# Altium schematic sheet Converter_HV.SchDoc("Converter High Voltage")
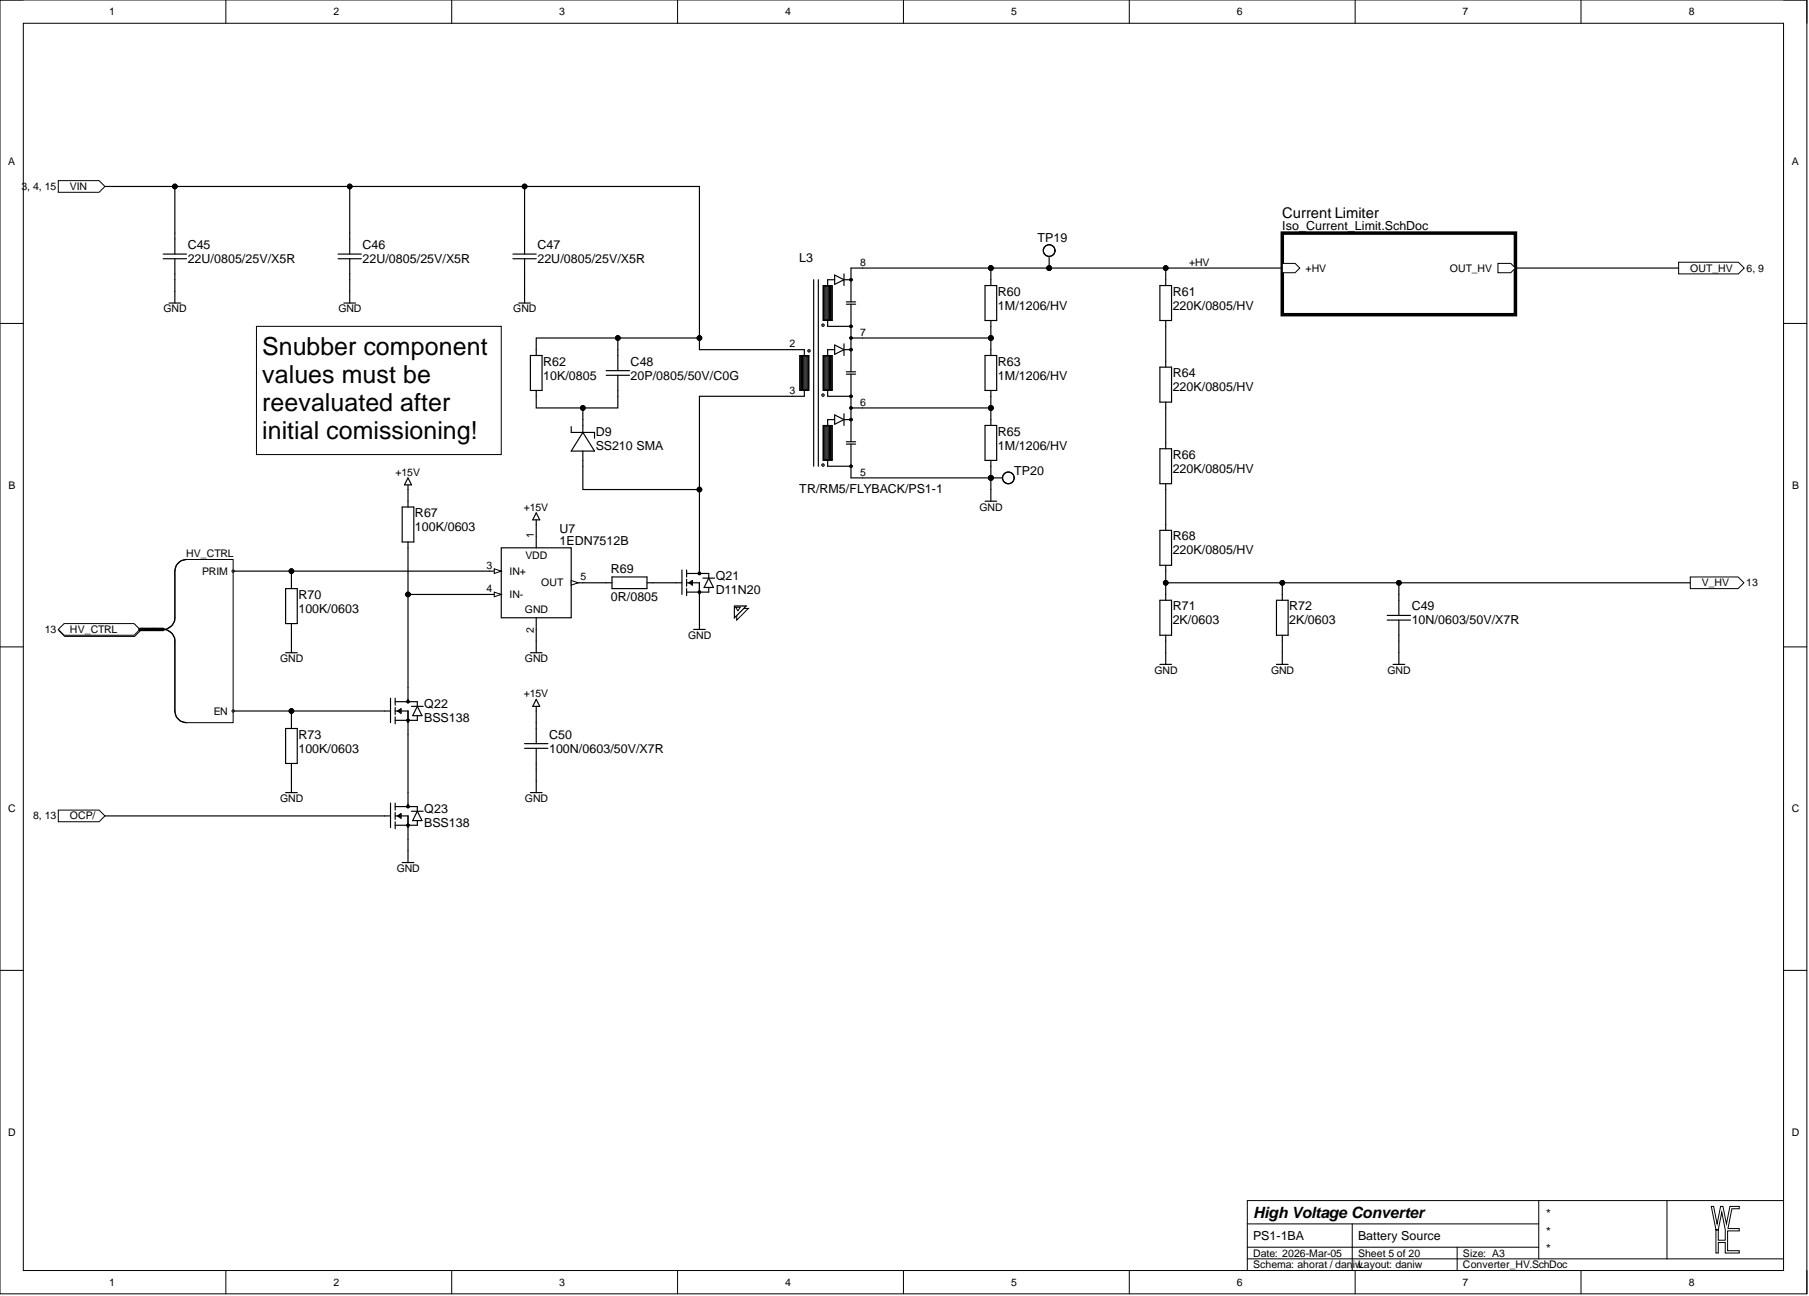
[diagram p0: Converter_HV.SchDoc]

## Parts
C45  22U/0805/25V/X5R  [CAP0805_X7R]
C46  22U/0805/25V/X5R  [CAP0805_X7R]
C47  22U/0805/25V/X5R  [CAP0805_X7R]
C48  20P/0805/50V/C0G  [CAP0805_C0G]
C49  10N/0603/50V/X7R  [CAP0603_X7R]
C50  100N/0603/50V/X7R  [CAP0603_X7R]
D9  SS210 SMA  value SS210  Manufacturer Part Number 3: SS210  [DIOM5226X230]
L3  TR/RM5/FLYBACK/PS1-1  [TR_FLYBACK_RM5_PS1-100]
Q21  D11N20  [TO228P990X229-3]
Q22  BSS138  [SOT2395P280X125-3-SE]
Q23  BSS138  [SOT2395P280X125-3-SE]
R60  1M/1206/HV  [R1206-0.80-WW]
R61  220K/0805/HV  [R0805-0.50-HV-WW]
R62  10K/0805  [R0805-0.50-WW]
R63  1M/1206/HV  [R1206-0.80-WW]
R64  220K/0805/HV  [R0805-0.50-HV-WW]
R65  1M/1206/HV  [R1206-0.80-WW]
R66  220K/0805/HV  [R0805-0.50-HV-WW]
R67  100K/0603  [R0603-0.40-WW]
R68  220K/0805/HV  [R0805-0.50-HV-WW]
R69  0R/0805  [R0805-0.50-WW]
R70  100K/0603  [R0603-0.40-WW]
R71  2K/0603  [R0603-0.40-WW]
R72  2K/0603  [R0603-0.40-WW]
R73  100K/0603  [R0603-0.40-WW]
TP19  TP_THD_1.5/0.8  [TP_THD_1.5/0.8]  (value not drawn)  (no BOM)
TP20  TP_THD_1.5/0.8  [TP_THD_1.5/0.8]  (value not drawn)  (no BOM)
U7  1EDN7512B  [SOT2395P280X125-5-NW]

## Ports
HV_CTRL, HV_CTRL.EN, HV_CTRL.PRIM, OCP/, OUT_HV, V_HV, VIN

## Nets
+15V: C50.1 R67.1 U7.1
GND: C45.2 C46.2 C47.2 C49.2 C50.2 L3.5 Q21.2 Q23.2 R65.2 R70.2 R71.2 R72.2 R73.2 TP20.1 U7.2
+HV: L3.8 R60.1 R61.1 TP19.1
OCP/: Q23.1 ; port OCP/
V_HV: C49.1 R68.2 R71.1 R72.1 ; port V_HV
VIN: C45.1 C46.1 C47.1 C48.1 L3.2 R62.1 ; port VIN
NetC48_2: C48.2 D9.1 R62.2
NetD9_2: D9.2 L3.3 Q21.3
NetL3_6: L3.6 R63.2 R65.1
NetL3_7: L3.7 R60.2 R63.1
NetQ21_1: Q21.1 R69.2
NetQ22_1: Q22.1 R73.1 ; port HV_CTRL
NetQ22_2: Q22.2 Q23.3
NetQ22_3: Q22.3 R67.2 U7.4(IN-)
NetR61_2: R61.2 R64.1
NetR64_2: R64.2 R66.1
NetR66_2: R66.2 R68.1
NetR69_1: R69.1 U7.5(OUT)
NetR70_1: R70.1 U7.3(IN+) ; port HV_CTRL
N00010: ; port OUT_HV

## Library data of the parts
C45-C47 = 22U/0805/25V/X5R: CAP SM 0805 22uF 25V X5R; Manufacturer: GENERIC; Manufacturer 1: Samsung Electro-Mechanics; Manufacturer Part Number 1: CL21A226MAYNNNE; Absolute Value: 2.2E-5; Database Table Name: C$; Eurocircuits GPN: GPN0805106-25; Component Kind: Standard; HelpURL: https://mm.digikey.com/Volume0/opasdata/d220001/medias/docus/339/CL21A226MAYNNNE_Spec.pdf; JLC Type: Basic; Manufacturer Part Number 3: CL21A226MAQNNNE; Package: 0805; Part Number: 22U/0805/25V/X5R; Supplier 1: Digi-Key; Supplier 3: LCSC; Supplier Part Number 1: 1276-CL21A226MAYNNNECT-ND; Supplier Part Number 3: C45783; Value: 22uF; Library Name: daniw_altium_lib.DbLib
C48 = 20P/0805/50V/C0G: CAP SM 0805 20pF 50V C0G; Manufacturer: GENERIC; Manufacturer 1: Samsung Electro-Mechanics; Manufacturer Part Number 1: CL21C200JBANNNC; Absolute Value: 2E-11; Database Table Name: C$; Component Kind: Standard; HelpURL: https://weblib.samsungsem.com/mlcc/mlcc-ec-data-sheet.do?partNumber=CL21C200JBANNN; JLC Type: Basic; Manufacturer Part Number 3: CL21C200JBANNNC; Package: 0805; Part Number: 20P/0805/50V/C0G; Supplier 1: Digi-Key; Supplier 3: LCSC; Supplier Part Number 1: 1276-1829-2-ND; Supplier Part Number 3: C1798; Value: 20pF; Library Name: daniw_altium_lib.DbLib
C49 = 10N/0603/50V/X7R: CAP SM 0603 10nF 50V X7R; Manufacturer: GENERIC; Manufacturer 1: YAGEO; Manufacturer Part Number 1: CC0603KPX7R9BB103; Absolute Value: 1E-8; Database Table Name: C$; Eurocircuits GPN: GPC0603103; Component Kind: Standard; HelpURL: https://www.yageo.com/upload/media/product/app/datasheet/mlcc/upy-gphc_x7r_6_3v-to-250v.pdf; Manufacturer 2: YAGEO; Manufacturer Part Number 2: CC0603KRX7R9BB103; Manufacturer Part Number 3: CC0603KRX7R9BB103; Package: 0603; Part Number: 10N/0603/50V/X7R; Supplier 1: Digi-Key; Supplier 2: Digi-Key; Supplier 3: LCSC; Supplier Part Number 1: 311-1572-1-ND; Supplier Part Number 2: 311-1085-1-ND; Supplier Part Number 3: C100042; Value: 10nF; Library Name: daniw_altium_lib.DbLib
C50 = 100N/0603/50V/X7R: CAP SM 0603 100nF 50V X7R; Manufacturer: GENERIC; Manufacturer 1: YAGEO; Manufacturer Part Number 1: CC0603KPX7R9BB104; Absolute Value: 1E-7; Database Table Name: C$; Eurocircuits GPN: GPC0603104; Component Kind: Standard; HelpURL: https://www.yageo.com/upload/media/product/app/datasheet/mlcc/upy-gphc_x7r_6_3v-to-250v.pdf; JLC Type: Basic; Manufacturer 2: YAGEO; Manufacturer Part Number 2: CC0603MRX7R9BB104; Manufacturer Part Number 3: CC0603KRX7R9BB104; Package: 0603; Part Number: 100N/0603/50V/X7R; Supplier 1: Digi-Key; Supplier 2: Digi-Key; Supplier 3: LCSC; Supplier Part Number 1: 311-3567-1-ND; Supplier Part Number 2: 311-4110-1-ND; Supplier Part Number 3: C14663; Value: 100nF; Library Name: daniw_altium_lib.DbLib
D9 = SS210 SMA: Si Shottky Diode 100V 2A DO-214AC; Manufacturer: GENERIC; Database Table Name: D$; Component Kind: Standard; HelpURL: https://www.lcsc.com/datasheet/C14996.pdf; JLC Type: Basic; Manufacturer 3: MDD(Microdiode Semiconductor); Package: DO-214AC, SMA; Part Number: SS210 SMA; Supplier 3: LCSC; Supplier Part Number 3: C14996; Library Name: daniw_altium_lib.DbLib
L3 = TR/RM5/FLYBACK/PS1-1: Database Table Name: L$; Component Kind: Standard; Package: RM5; Part Number: TR/RM5/FLYBACK/PS1-1; Library Name: daniw_altium_lib.DbLib
Q21 = D11N20: N-Channel MOSFET 200V 11A; Manufacturer: Jingdao Microelectronics; Database Table Name: T$; Component Kind: Standard; HelpURL: https://www.lcsc.com/datasheet/C2935478.pdf; JLC Type: Extended; Manufacturer 3: Jingdao Microelectronics; Manufacturer Part Number 3: D11N20; Package: TO-252AA; Part Number: D11N20; Supplier 3: LCSC; Supplier Part Number 3: C2935478; Library Name: daniw_altium_lib.DbLib
Q22 Q23 = BSS138: N-Kanal 50 V 220mA (Ta) 350mW (Ta) Oberflächenmontage SOT-23; Manufacturer: GENERIC; Manufacturer 1: ANBON SEMICONDUCTOR (INT'L) LIMITED; Database Table Name: T$; Component Kind: Standard; HelpURL: https://mm.digikey.com/Volume0/opasdata/d220001/medias/docus/3037/BSS138.pdf; JLC Type: Extended; Manufacturer 2: FOSAN; Manufacturer 3: FOSAN; Manufacturer Part Number 1: BSS138; Manufacturer Part Number 2: BSS138; Manufacturer Part Number 3: BSS138; Package: SOT23; Part Number: BSS138; Supplier 1: Digi-Key; Supplier 2: LCSC; Supplier 3: LCSC; Supplier Part Number 1: 4530-BSS138CT-ND; Supplier Part Number 2: C2926186; Supplier Part Number 3: C2926186; Library Name: daniw_altium_lib.DbLib
R60 R63 R65 = 1M/1206/HV: RES SM 1206 1M High voltage; Manufacturer: GENERIC; Manufacturer 1: YAGEO; Manufacturer Part Number 1: RV1206FR-071ML; Absolute Value: 1000000; Database Table Name: R$; Component Kind: Standard; HelpURL: https://www.yageogroup.com/content/datasheet/asset/file/PYU-RV_51_ROHS_L; JLC Type: Extended; Manufacturer 3: YAGEO; Manufacturer Part Number 3: RV1206FR-071ML; Package: 1206; Part Number: 1M/1206/HV; Supplier 1: Digi-Key; Supplier 3: LCSC; Supplier Part Number 1: 311-1MNICT-ND; Supplier Part Number 3: C706459; Value: 1M; Library Name: daniw_altium_lib.DbLib
R61 R64 R66 R68 = 220K/0805/HV: RES SM 0805 220K High voltage; Manufacturer: GENERIC; Manufacturer 1: YAGEO; Absolute Value: 220000; Database Table Name: R$; Component Kind: Standard; HelpURL: https://www.yageogroup.com/content/datasheet/asset/file/PYU-RV_51_ROHS_L; JLC Type: Extended; Manufacturer 3: YAGEO; Manufacturer Part Number 3: RV0805FR-07220KL; Package: 0805; Part Number: 220K/0805/HV; Supplier 3: LCSC; Supplier Part Number 3: C873358; Value: 220K; Library Name: daniw_altium_lib.DbLib
R62 = 10K/0805: RES SM 0805 10K; Manufacturer: GENERIC; Manufacturer 1: YAGEO; Manufacturer Part Number 1: RC0805FR-1310KL; Absolute Value: 10000; Database Table Name: R$; Eurocircuits GPN: GPR080510K; Component Kind: Standard; HelpURL: https://www.yageogroup.com/content/datasheet/asset/file/PYU-RC_GROUP_51_ROHS_L; JLC Type: Basic; Manufacturer 2: YAGEO; Manufacturer 3: UNI-ROYAL(Uniroyal Elec); Manufacturer Part Number 2: RC0805FR-1010KL; Manufacturer Part Number 3: 0805W8F1002T5E; Package: 0805; Part Number: 10K/0805; Supplier 1: Digi-Key; Supplier 2: Digi-Key; Supplier 3: LCSC; Supplier Part Number 1: 13-RC0805FR-1310KLCT-ND; Supplier Part Number 2: 13-RC0805FR-1010KLCT-ND; Supplier Part Number 3: C17414; Value: 10K; Library Name: daniw_altium_lib.DbLib
R67 R70 R73 = 100K/0603: RES SM 0603 100K; Manufacturer: GENERIC; Manufacturer 1: YAGEO; Manufacturer Part Number 1: RC0603FR-13100KL; Absolute Value: 100000; Database Table Name: R$; Eurocircuits GPN: GPR0603100K; Component Kind: Standard; HelpURL: https://www.yageogroup.com/content/datasheet/asset/file/PYU-RC_GROUP_51_ROHS_L; JLC Type: Basic; Manufacturer 2: YAGEO; Manufacturer 3: UNI-ROYAL(Uniroyal Elec); Manufacturer Part Number 2: RC0603FR-10100KL; Manufacturer Part Number 3: 0603WAF1003T5E; Package: 0603; Part Number: 100K/0603; Supplier 1: Digi-Key; Supplier 2: Digi-Key; Supplier 3: LCSC; Supplier Part Number 1: 13-RC0603FR-13100KLCT-ND; Supplier Part Number 2: 13-RC0603FR-10100KLCT-ND; Supplier Part Number 3: C25803; Value: 100K; Library Name: daniw_altium_lib.DbLib
R69 = 0R/0805: RES SM 0805 1R; Manufacturer: GENERIC; Manufacturer 1: YAGEO; Manufacturer Part Number 1: RC0805FR-130RL; Absolute Value: 0; Database Table Name: R$; Eurocircuits GPN: GPR08050R; Value: 0R; Component Kind: Standard; HelpURL: https://www.yageogroup.com/content/datasheet/asset/file/PYU-RC_GROUP_51_ROHS_L; JLC Type: Basic; Manufacturer 2: YAGEO; Manufacturer 3: UNI-ROYAL(Uniroyal Elec); Manufacturer Part Number 2: RC0805FR-100RL; Manufacturer Part Number 3: 0805W8F0000T5E; Package: 0805; Part Number: 0R/0805; Supplier 1: Digi-Key; Supplier 2: Digi-Key; Supplier 3: LCSC; Supplier Part Number 1: 13-RC0805FR-130RLCT-ND; Supplier Part Number 2: 13-RC0805FR-100RLCT-ND; Supplier Part Number 3: C17477; Library Name: daniw_altium_lib.DbLib
R71 R72 = 2K/0603: RES SM 0603 2K; Manufacturer: GENERIC; Manufacturer 1: YAGEO; Manufacturer Part Number 1: RC0603FR-132KL; Absolute Value: 2000; Database Table Name: R$; Eurocircuits GPN: GPR06032K; Component Kind: Standard; HelpURL: https://www.yageogroup.com/content/datasheet/asset/file/PYU-RC_GROUP_51_ROHS_L; JLC Type: Basic; Manufacturer 2: YAGEO; Manufacturer 3: UNI-ROYAL(Uniroyal Elec); Manufacturer Part Number 2: RC0603FR-102KL; Manufacturer Part Number 3: 0603WAF2001T5E; Package: 0603; Part Number: 2K/0603; Supplier 1: Digi-Key; Supplier 2: Digi-Key; Supplier 3: LCSC; Supplier Part Number 1: 13-RC0603FR-132KLCT-ND; Supplier Part Number 2: 13-RC0603FR-102KLCT-ND; Supplier Part Number 3: C22975; Value: 2K; Library Name: daniw_altium_lib.DbLib
TP19 TP20 = TP_THD_1.5/0.8: THT Test Point 1.5/0.8mm; Manufacturer: NONE; Database Table Name: Misc$; Part Number: TP_THD_1.5/0.8; Library Name: daniw_altium_lib.DbLib
U7 = 1EDN7512B: 1EDN7512B Single-channel low-side gate driver IC with 4 A source/8 A sink output stage; Manufacturer: Infineon Technologies; Manufacturer Part Number 1: 1EDN7512BXTSA1; Database Table Name: Integrated$; Component Kind: Standard; HelpURL: https://www.infineon.com/dgdl/Infineon-1DN751x_1EDN851x_Rev+2.0-DS-v02_00-EN.pdf; Manufacturer 1: Infineon Technologies; Manufacturer 3: Infineon Technologies; Manufacturer Part Number 3: 1EDN7512B; Package: SOT23-5; Part Number: 1EDN7512B; Supplier 1: Digi-Key; Supplier 3: LCSC; Supplier Part Number 1: 1EDN7512BXTSA1CT-ND; Supplier Part Number 3: C153236; Library Name: daniw_altium_lib.DbLib
